# Altium schematic sheet ENTHU1.SchDoc(ENTHU1)
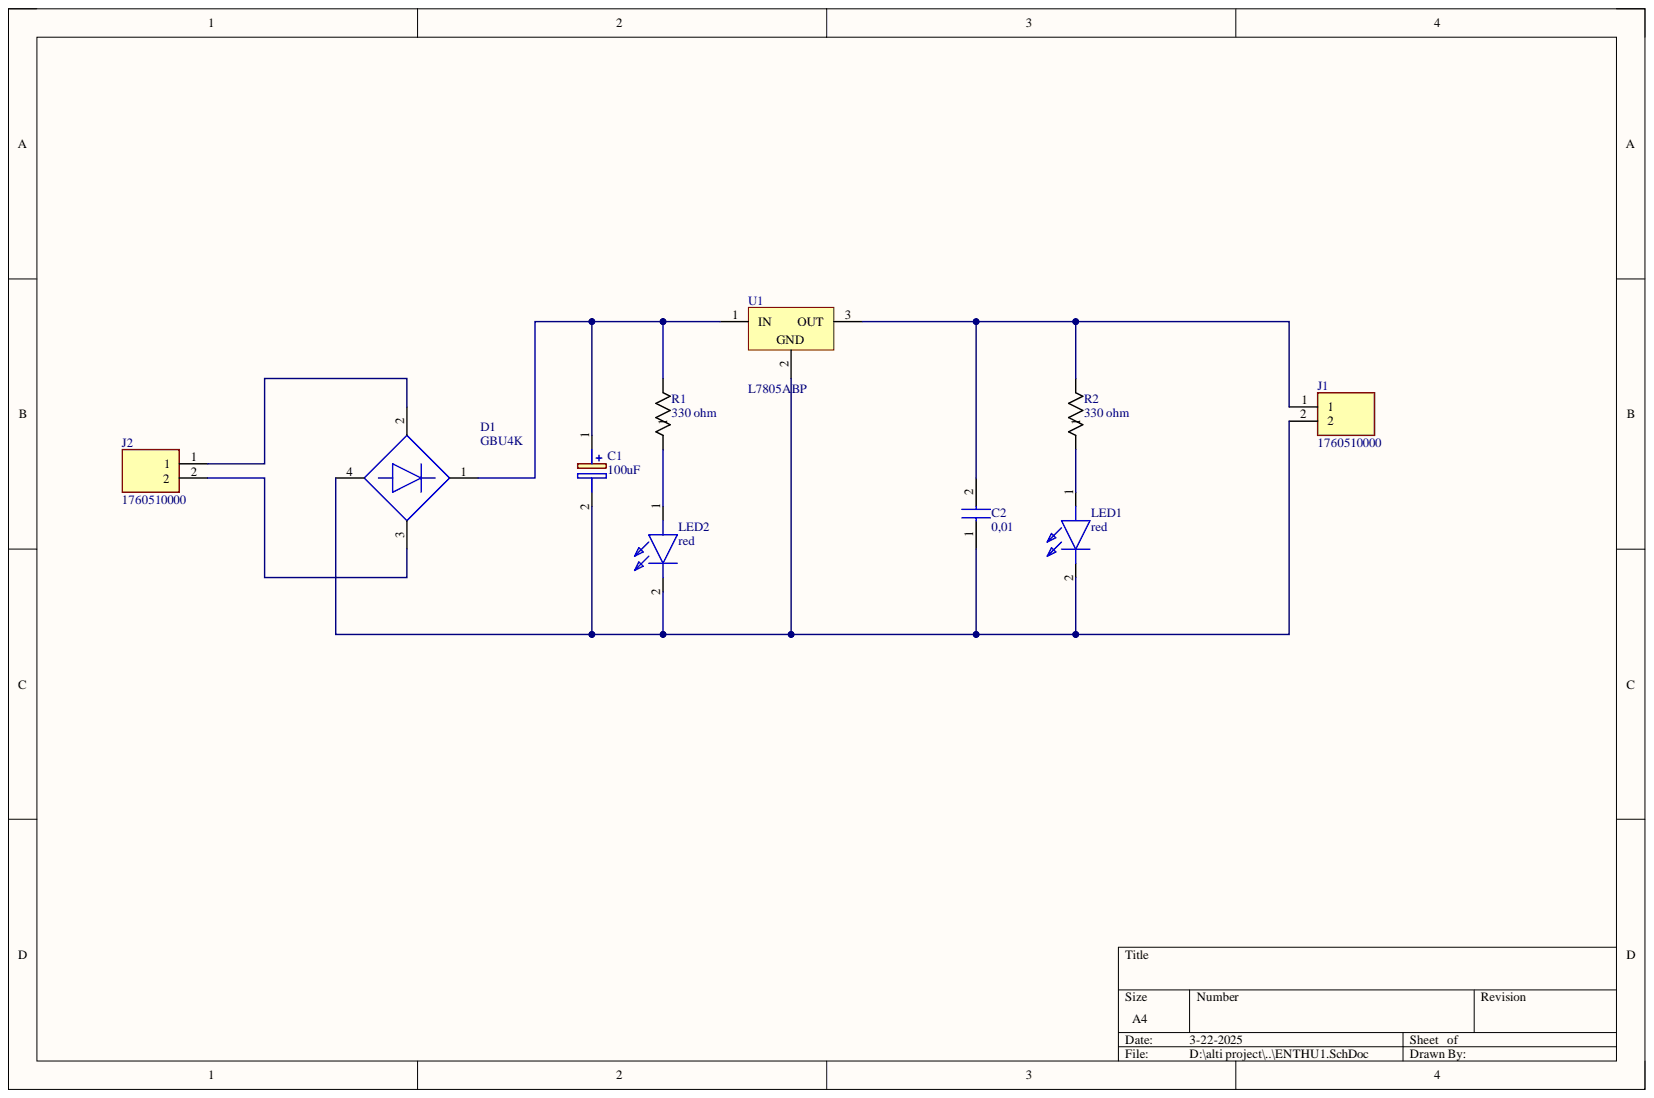
[diagram p0: ENTHU1.SchDoc]

## Parts
C1  100uF  [CAPPRD250W55D630H1270]
C2  0,01  [FA24]
D1  GBU4K  [FP-127EL-MFG]
J1  1760510000  [1760510000]
J2  1760510000  [1760510000]
LED1  red  [TLLR4400]
LED2  red  [TLLR4400]
R1  330 ohm  [RES_AC05_VIS]
R2  330 ohm  [RES_AC05_VIS]
U1  L7805ABP  [TO220FP]

## Nets
NetC1_1: C1.1 D1.1 R1.2 U1.1
NetC1_2: C1.2 C2.1 D1.4 J1.2 LED1.2 LED2.2 U1.2
NetC2_2: C2.2 J1.1 R2.2 U1.3
NetD1_2: D1.2 J2.1
NetD1_3: D1.3 J2.2
NetLED1_1: LED1.1 R2.1
NetLED2_1: LED2.1 R1.1

## Library data of the parts
C1 = EEUFR1E101B: Capacitor Polarised; Manufacturer_Name: Panasonic; Component Kind: Standard; Datasheet Link: http://industrial.panasonic.com/cdbs/www-data/pdf/RDF0000/ABA0000C1259.pdf; Height: 12.7mm; Manufacturer_Part_Number: EEUFR1E101B
C2 = FA24NP02E103JNU06: Capacitor; Manufacturer_Name: TDK; Arrow Part Number: FA24NP02E103JNU06; Arrow Price/Stock: https://www.arrow.com/en/products/fa24np02e103jnu06/tdk?region=nac; Component Kind: Standard; Datasheet Link: https://product.tdk.com/system/files/dam/doc/product/capacitor/ceramic/lead-mlcc/catalog/leadmlcc_halogenfree_fa150_en.pdf; Height: 7mm; Manufacturer_Part_Number: FA24NP02E103JNU06; Mouser Part Number: 810-FA24NP2E103JNU06; Mouser Price/Stock: https://www.mouser.co.uk/ProductDetail/TDK/FA24NP02E103JNU06?qs=5aG0NVq1C4xj1OJ153ewVg%3D%3D
D1 = CMP-00278-84328811-1: GBU4K Diode Rectifier Bridge Single 800V 4A 4-Pin Case GBU Rail; Manufacturer Lifecycle Status: ACTIVE; Capacitance: 200pF; Forward Voltage: 1V; Max Power Dissipation: 8W; Max Surge Current: 150A; Number of Elements: 1; Peak Reverse Current: 5µA; Reverse Voltage (DC): 560V; Case/Package: SIP; Component Kind: Standard; Current: 4A; Current Rating: 4A; Element Configuration: Single; Forward Current: 4A; Height: 18.8mm; Lead Free: Lead Free; Length: 22.3mm; Library Name: Altium Content Vault; Lifecycle Status: Production; Max Operating Temperature: 150°C; Max Repetitive Reverse Voltage (Vrrm): 800V; Min Operating Temperature: -55°C; Mount: Through Hole; NexarPartId: 84328811; Number of Pins: 4; Output Current: 4A; Peak Non-Repetitive Surge Current: 150A; Radiation Hardening: No; RoHS: Compliant; Termination: Through Hole; Voltage: 800V; Voltage Rating (DC): 800V; Weight: 5.399989g; Width: 3.56mm
J1 J2 = 1760510000: Connector; Manufacturer_Name: Weidmuller; Component Kind: Standard; Datasheet Link: http://catalog.weidmueller.com/createDatasheetForMobile.do?ordernumber=1760510000&scope1=1&scope2=1&localeId=en; Height: 10mm; Manufacturer_Part_Number: 1760510000; Mouser Part Number: 470-1760510000; Mouser Price/Stock: https://www.mouser.co.uk/ProductDetail/Weidmuller/1760510000?qs=BTG2th8YmhTa8qcglSF3eg%3D%3D
LED1 LED2 = TLLR4400: LED; Manufacturer_Name: Vishay; Arrow Part Number: TLLR4400; Arrow Price/Stock: https://www.arrow.com/en/products/tllr4400/vishay?region=europe; Component Kind: Standard; Datasheet Link: https://www.mouser.com/datasheet/2/427/tllg440-240378.pdf; Height: 6.1mm; Manufacturer_Part_Number: TLLR4400; Mouser Part Number: 78-TLLR4400; Mouser Price/Stock: https://www.mouser.co.uk/ProductDetail/Vishay-Semiconductors/TLLR4400?qs=%2Fjqivxn91ccDW9KTa57RWQ%3D%3D
R1 R2 = CMP-00030-39745953-1: AC05000003300JAC00 VISHAY AC05000003300JAC00 Through Hole Resistor, 330 ohm, Axial Leaded, 5 W, 5%, AC Series; Lead Diameter: 800µm; Max Power Dissipation: 5W; Resistance: 330Ω; Temperature Coefficient: -10ppm/°C; Voltage Rating (DC): 40V; Case/Package: Axial; Component Kind: Standard; Composition: Wirewound; Contact Plating: Tin; Diameter: 7.5mm; Features: Flame Retardant Coating; Lead Free: Lead Free; Length: 18mm; Library Name: Altium Content Vault; Max Operating Temperature: 275°C; Military Standard: Not; Min Operating Temperature: -40°C; NexarPartId: 39745953; Number of Pins: 2; Number of Terminations: 2; Packaging: Cut Tape (CT); Power Rating: 5W; Radiation Hardening: No; REACH SVHC: Unknown; RoHS: Compliant; Schedule B: 8533210080, 8533210080; Termination: Axial; Tolerance: 5%; Width: 7.49mm
U1 = CMP-0244-00479-1: Positive Voltage Regulator, 5V, 3-Pin TO-220FP; Component Kind: Standard; ComponentLink1Description: Manufacturer URL; ComponentLink1URL: http://www.st.com; ComponentLink2Description: Datasheet; ComponentLink2URL: http://www.st.com/internet/com/TECHNICAL_RESOURCES/TECHNICAL_LITERATURE/DATASHEET/CD00000444.pdf; DatasheetVersion: 16/11/2011; Library Name: Altium Content Vault; PackageDescription: TO, Thru-Hole, Vertical, Heatsink Mounted; 3 In-Line Leads; Pitch 2.54 mm; PackageReference: TO220FP; PackageVersion: 2011
